# Altium schematic sheet PAGE10 HDMI.SchDoc
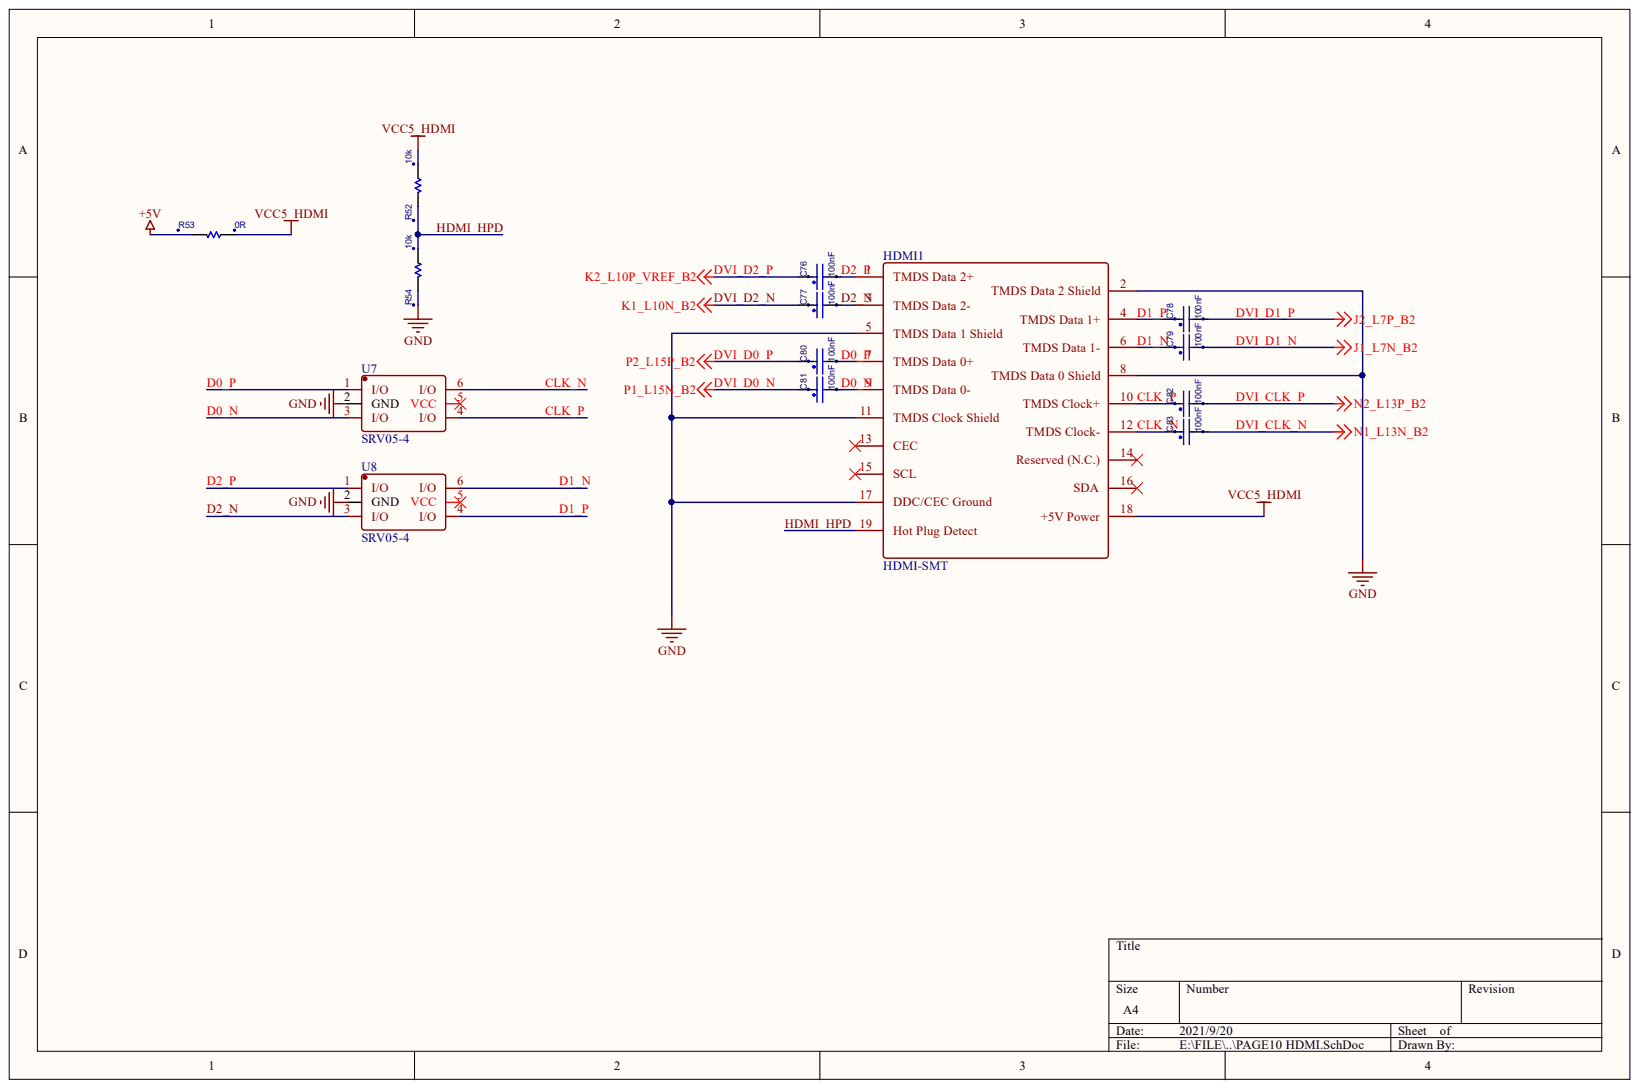
[diagram p0: PAGE10 HDMI.SchDoc]

## Parts
C76  100nF  [C0402]
C77  100nF  [C0402]
C78  100nF  [C0402]
C79  100nF  [C0402]
C80  100nF  [C0402]
C81  100nF  [C0402]
C82  100nF  [C0402]
C83  100nF  [C0402]
HDMI1  HDMI-SMT  [HDMI-SMT-19Pin]
R52  10k  [R0402]
R53  0R  [R0402]
R54  10k  [R0402]
U7  SRV05-4  [SOT23-6]
U8  SRV05-4  [SOT23-6]

## Nets
+5V: R53.2
GND: HDMI1.2(TMDS_Data_2_Shield) HDMI1.5(TMDS_Data_1_Shield) HDMI1.8(TMDS_Data_0_Shield) HDMI1.11(TMDS_Clock_Shield) HDMI1.17(DDC/CEC_Ground) R54.2 U7.2(GND) U8.2(GND)
VCC5_HDMI: HDMI1.18(+5V_Power) R52.1 R53.1
CLK_N: C83.1 HDMI1.12(TMDS_Clock-) U7.6(I/O)
CLK_P: C82.1 HDMI1.10(TMDS_Clock+) U7.4(I/O)
D0_N: C81.2 HDMI1.9(TMDS_Data_0-) U7.3(I/O)
D0_P: C80.2 HDMI1.7(TMDS_Data_0+) U7.1(I/O)
D1_N: C79.1 HDMI1.6(TMDS_Data_1-) U8.6(I/O)
D1_P: C78.1 HDMI1.4(TMDS_Data_1+) U8.4(I/O)
D2_N: C77.2 HDMI1.3(TMDS_Data_2-) U8.3(I/O)
D2_P: C76.2 HDMI1.1(TMDS_Data_2+) U8.1(I/O)
DVI_CLK_N: C83.2
DVI_CLK_P: C82.2
DVI_D0_N: C81.1
DVI_D0_P: C80.1
DVI_D1_N: C79.2
DVI_D1_P: C78.2
DVI_D2_N: C77.1
DVI_D2_P: C76.1
HDMI_HPD: HDMI1.19(Hot_Plug_Detect) R52.2 R54.1

Not connected: HDMI1 13(CEC) 14(Reserved_(N.C.)) 15(SCL) 16(SDA); U7 5(VCC); U8 5(VCC)

## Library data of the parts
C76-C83 = CAP_NP: CAP CER 0.1UF 6.3V 10% X5R 0201; AGILE_PN: 150-75429; CAD_APPROVED: Yes; FPLIST: CC0201,CC0201_HD,CC0201_3PIN,0201_CC; MFG_NAME01: MURATA; MFG_PN01: GRM033R60J104KE19D; Component Kind: Standard; HEIGHT: 0.33MM; ROHS: Yes; Tolerance: 10%
R52-R54 = SMALL R1: Component Kind: Standard
HDMI1 = HDMI-SMT: Component Kind: Standard; Library Name: 视频接口.IntLib
U7 U8 = SRV05-4: Component Kind: Standard; Library Name: 未分类IC.IntLib
